# Altium schematic sheet [05] - MCU.SchDoc("[05] - MCU")
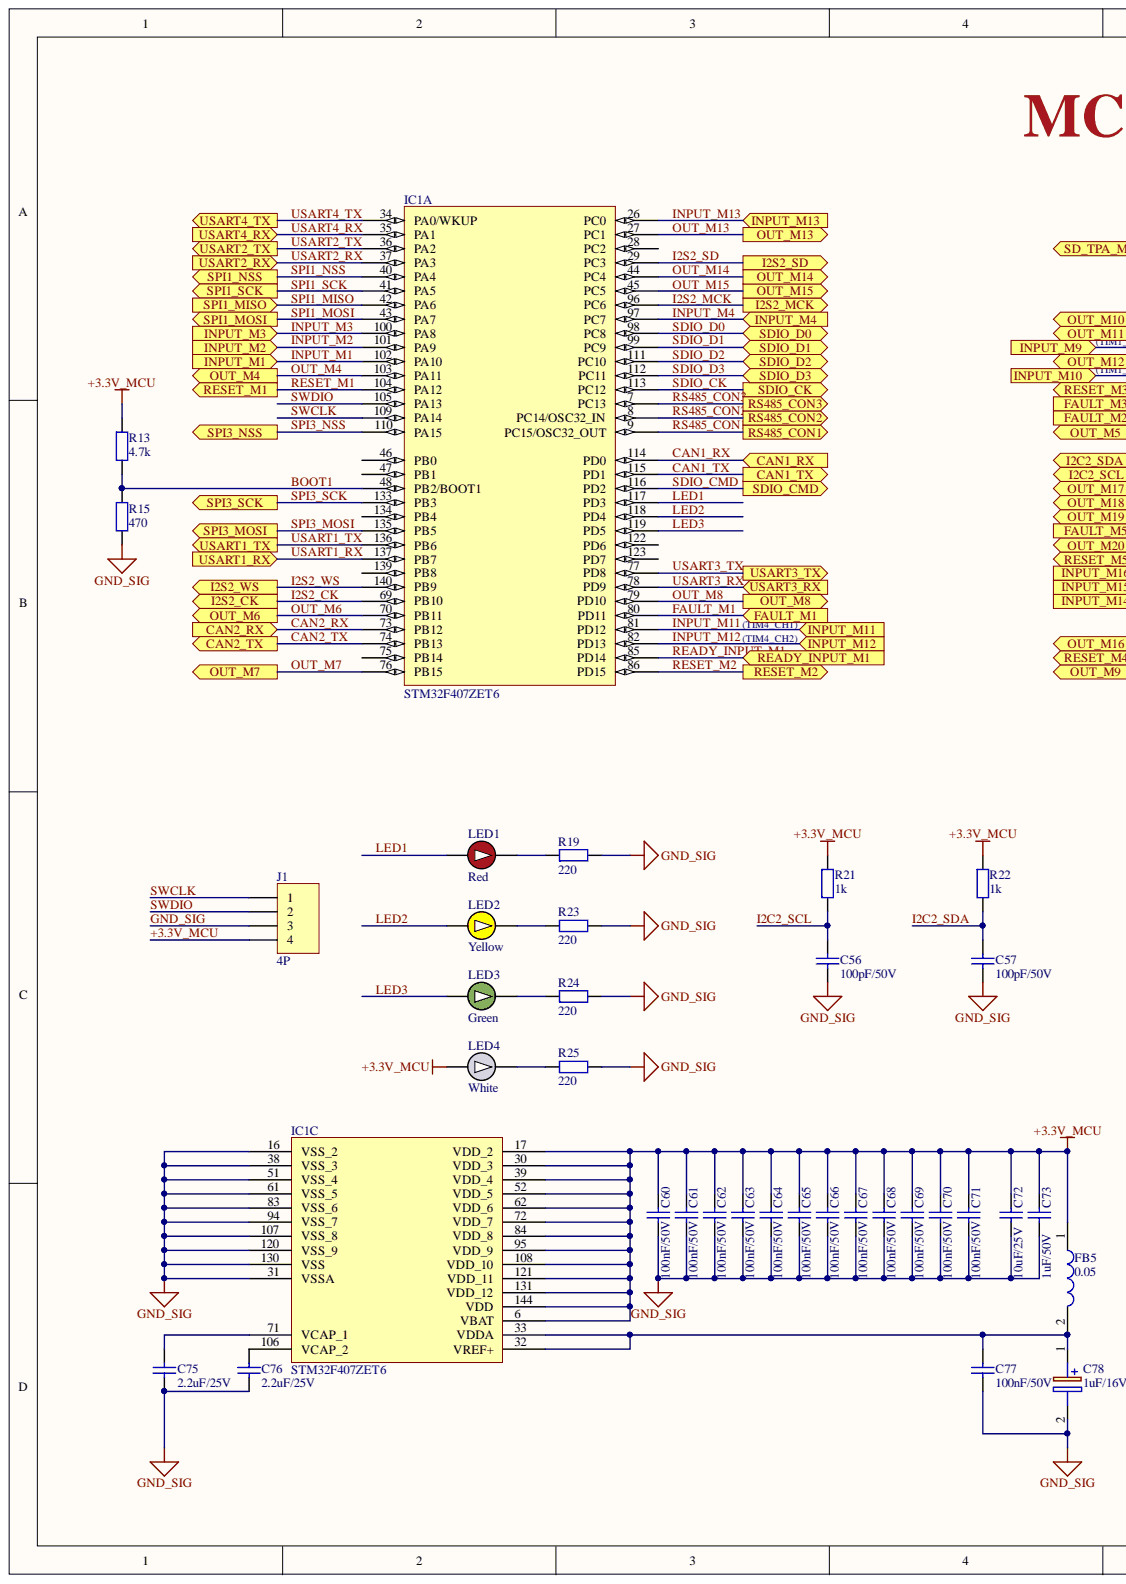
[diagram p0: [05] - MCU.SchDoc, left]
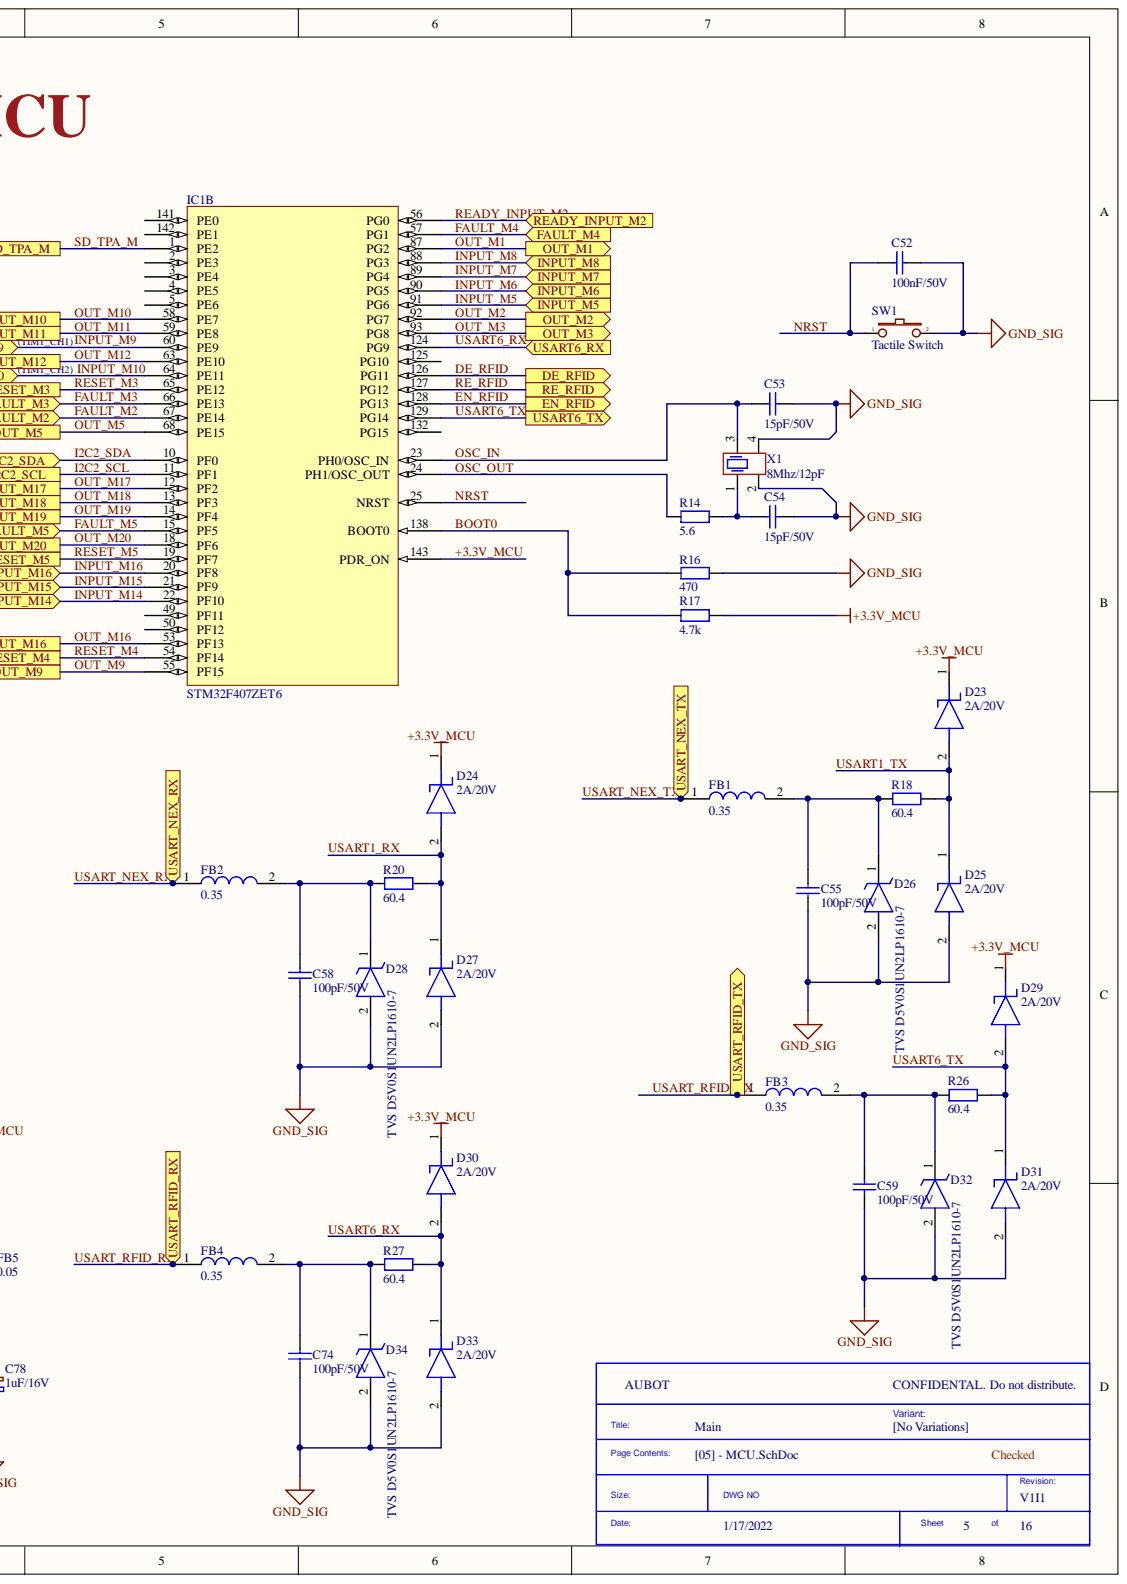
[diagram p1: [05] - MCU.SchDoc, right]

## Parts
C52  100nF/50V  [CAP 0805]
C53  15pF/50V  [CAP 0805]
C54  15pF/50V  [CAP 0805]
C55  100pF/50V  [CAP 0805]
C56  100pF/50V  [CAP 0805]
C57  100pF/50V  [CAP 0805]
C58  100pF/50V  [CAP 0805]
C59  100pF/50V  [CAP 0805]
C60  100nF/50V  [CAP 0805]
C61  100nF/50V  [CAP 0805]
C62  100nF/50V  [CAP 0805]
C63  100nF/50V  [CAP 0805]
C64  100nF/50V  [CAP 0805]
C65  100nF/50V  [CAP 0805]
C66  100nF/50V  [CAP 0805]
C67  100nF/50V  [CAP 0805]
C68  100nF/50V  [CAP 0805]
C69  100nF/50V  [CAP 0805]
C70  100nF/50V  [CAP 0805]
C71  100nF/50V  [CAP 0805]
C72  10uF/25V  [CAP 0805]
C73  1uF/50V  [CAP 0805]
C74  100pF/50V  [CAP 0805]
C75  2.2uF/25V  [CAP 0805]
C76  2.2uF/25V  [CAP 0805]
C77  100nF/50V  [CAP 0805]
C78  1uF/16V  [CAP TANT 1206]
D23  2A/20V  [DIODE SOD-323-2]
D24  2A/20V  [DIODE SOD-323-2]
D25  2A/20V  [DIODE SOD-323-2]
D26  TVS D5V0S1UN2LP1610-7  Manufacturer Part Number: D5V0S1UN2LP1610-7  [DFN110P158X55-2N]
D27  2A/20V  [DIODE SOD-323-2]
D28  TVS D5V0S1UN2LP1610-7  Manufacturer Part Number: D5V0S1UN2LP1610-7  [DFN110P158X55-2N]
D29  2A/20V  [DIODE SOD-323-2]
D30  2A/20V  [DIODE SOD-323-2]
D31  2A/20V  [DIODE SOD-323-2]
D32  TVS D5V0S1UN2LP1610-7  Manufacturer Part Number: D5V0S1UN2LP1610-7  [DFN110P158X55-2N]
D33  2A/20V  [DIODE SOD-323-2]
D34  TVS D5V0S1UN2LP1610-7  Manufacturer Part Number: D5V0S1UN2LP1610-7  [DFN110P158X55-2N]
FB1  0.35  [RES 0603]
FB2  0.35  [RES 0603]
FB3  0.35  [RES 0603]
FB4  0.35  [RES 0603]
FB5  0.05  [RES 0603]
IC1  STM32F407ZET6  [LQFP-144]  (parts A,B,C)
J1  4P  [HEADER 2.54 4P VERTICAL]
LED1  Red  [LED 0805]
LED2  Yellow  [LED 0805]
LED3  Green  [LED 0805]
LED4  White  [LED 0805]
R13  4.7k  [RES 0805]
R14  5.6  [RES 0805]
R15  470  [RES 0805]
R16  470  [RES 0805]
R17  4.7k  [RES 0805]
R18  60.4  [RES 0805]
R19  220  [RES 0805]
R20  60.4  [RES 0805]
R21  1k  [RES 0805]
R22  1k  [RES 0805]
R23  220  [RES 0805]
R24  220  [RES 0805]
R25  220  [RES 0805]
R26  60.4  [RES 0805]
R27  60.4  [RES 0805]
SW1  Tactile Switch  [Tactile Switch 6x3.5]
X1  8Mhz/12pF  [CRYSTAL 4P 3.2X2.5]

## Ports
CAN1_RX, CAN1_TX, CAN2_RX, CAN2_TX, DE_RFID, EN_RFID, FAULT_M1, FAULT_M2, FAULT_M3, FAULT_M4, FAULT_M5, I2C2_SCL, I2C2_SDA, I2S2_CK, I2S2_MCK, I2S2_SD, I2S2_WS, INPUT_M1, INPUT_M2, INPUT_M3, INPUT_M4, INPUT_M5, INPUT_M6, INPUT_M7, INPUT_M8, INPUT_M9, INPUT_M10, INPUT_M11, INPUT_M12, INPUT_M13, INPUT_M14, INPUT_M15, INPUT_M16, OUT_M1, OUT_M2, OUT_M3, OUT_M4, OUT_M5, OUT_M6, OUT_M7, OUT_M8, OUT_M9, OUT_M10, OUT_M11, OUT_M12, OUT_M13, OUT_M14, OUT_M15, OUT_M16, OUT_M17, OUT_M18, OUT_M19, OUT_M20, RE_RFID, READY_INPUT_M1, READY_INPUT_M2, RESET_M1, RESET_M2, RESET_M3, RESET_M4, RESET_M5, RS485_CON1, RS485_CON2, RS485_CON3, SD_TPA_M, SDIO_CK, SDIO_CMD, SDIO_D0, SDIO_D1, SDIO_D2, SDIO_D3, SPI1_MISO, SPI1_MOSI, SPI1_NSS, SPI1_SCK, SPI3_MOSI, SPI3_NSS, SPI3_SCK, USART1_RX, USART1_TX, USART2_RX, USART2_TX, USART3_RX, USART3_TX, USART4_RX, USART4_TX, USART6_RX, USART6_TX, USART_NEX_RX, USART_NEX_TX, USART_RFID_RX, USART_RFID_TX

## Nets
+3.3V_MCU: C60.2 C61.2 C62.2 C63.2 C64.2 C65.2 C66.2 C67.2 C68.2 C69.2 C70.2 C71.2 C72.2 C73.2 D23.1 D24.1 D29.1 D30.1 FB5.1 IC1.6(VBAT) IC1.17(VDD_2) IC1.30(VDD_3) IC1.39(VDD_4) IC1.52(VDD_5) IC1.62(VDD_6) IC1.72(VDD_7) IC1.84(VDD_8) IC1.95(VDD_9) IC1.108(VDD_10) IC1.121(VDD_11) IC1.131(VDD_12) IC1.143(PDR_ON) IC1.144(VDD) J1.4 LED4.1 R13.1 R17.2 R21.1 R22.1
BOOT0: IC1.138(BOOT0) R16.1 R17.1
BOOT1: IC1.48(PB2/BOOT1) R13.2 R15.1
CAN1_RX: IC1.114(PD0) ; port CAN1_RX
CAN1_TX: IC1.115(PD1) ; port CAN1_TX
CAN2_RX: IC1.73(PB12) ; port CAN2_RX
CAN2_TX: IC1.74(PB13) ; port CAN2_TX
DE_RFID: IC1.126(PG11) ; port DE_RFID
EN_RFID: IC1.128(PG13) ; port EN_RFID
FAULT_M1: IC1.80(PD11) ; port FAULT_M1
FAULT_M2: IC1.67(PE14) ; port FAULT_M2
FAULT_M3: IC1.66(PE13) ; port FAULT_M3
FAULT_M4: IC1.57(PG1) ; port FAULT_M4
FAULT_M5: IC1.15(PF5) ; port FAULT_M5
GND_SIG: C52.2 C53.1 C54.1 C55.2 C56.2 C57.2 C58.2 C59.2 C60.1 C61.1 C62.1 C63.1 C64.1 C65.1 C66.1 C67.1 C68.1 C69.1 C70.1 C71.1 C72.1 C73.1 C74.2 C75.1 C76.1 C77.1 C78.2 D25.2 D26.2 D27.2 D28.2 D31.2 D32.2 D33.2 D34.2 IC1.16(VSS_2) IC1.31(VSSA) IC1.38(VSS_3) IC1.51(VSS_4) IC1.61(VSS_5) IC1.83(VSS_6) IC1.94(VSS_7) IC1.107(VSS_8) IC1.120(VSS_9) IC1.130(VSS) J1.3 R15.2 R16.2 R19.2 R23.2 R24.2 R25.2 SW1.2 X1.2 X1.4
I2C2_SCL: C56.1 IC1.11(PF1) R21.2 ; port I2C2_SCL
I2C2_SDA: C57.1 IC1.10(PF0) R22.2 ; port I2C2_SDA
I2S2_CK: IC1.69(PB10) ; port I2S2_CK
I2S2_MCK: IC1.96(PC6) ; port I2S2_MCK
I2S2_SD: IC1.29(PC3) ; port I2S2_SD
I2S2_WS: IC1.140(PB9) ; port I2S2_WS
INPUT_M1: IC1.102(PA10) ; port INPUT_M1
INPUT_M2: IC1.101(PA9) ; port INPUT_M2
INPUT_M3: IC1.100(PA8) ; port INPUT_M3
INPUT_M4: IC1.97(PC7) ; port INPUT_M4
INPUT_M5: IC1.91(PG6) ; port INPUT_M5
INPUT_M6: IC1.90(PG5) ; port INPUT_M6
INPUT_M7: IC1.89(PG4) ; port INPUT_M7
INPUT_M8: IC1.88(PG3) ; port INPUT_M8
INPUT_M9: IC1.60(PE9) ; port INPUT_M9
INPUT_M10: IC1.64(PE11) ; port INPUT_M10
INPUT_M11: IC1.81(PD12) ; port INPUT_M11
INPUT_M12: IC1.82(PD13) ; port INPUT_M12
INPUT_M13: IC1.26(PC0) ; port INPUT_M13
INPUT_M14: IC1.22(PF10) ; port INPUT_M14
INPUT_M15: IC1.21(PF9) ; port INPUT_M15
INPUT_M16: IC1.20(PF8) ; port INPUT_M16
LED1: IC1.117(PD3) LED1.1
LED2: IC1.118(PD4) LED2.1
LED3: IC1.119(PD5) LED3.1
NRST: C52.1 IC1.25(NRST) SW1.1
OSC_IN: C53.2 IC1.23(PH0/OSC_IN) X1.3
OSC_OUT: IC1.24(PH1/OSC_OUT) R14.1
OUT_M1: IC1.87(PG2) ; port OUT_M1
OUT_M2: IC1.92(PG7) ; port OUT_M2
OUT_M3: IC1.93(PG8) ; port OUT_M3
OUT_M4: IC1.103(PA11) ; port OUT_M4
OUT_M5: IC1.68(PE15) ; port OUT_M5
OUT_M6: IC1.70(PB11) ; port OUT_M6
OUT_M7: IC1.76(PB15) ; port OUT_M7
OUT_M8: IC1.79(PD10) ; port OUT_M8
OUT_M9: IC1.55(PF15) ; port OUT_M9
OUT_M10: IC1.58(PE7) ; port OUT_M10
OUT_M11: IC1.59(PE8) ; port OUT_M11
OUT_M12: IC1.63(PE10) ; port OUT_M12
OUT_M13: IC1.27(PC1) ; port OUT_M13
OUT_M14: IC1.44(PC4) ; port OUT_M14
OUT_M15: IC1.45(PC5) ; port OUT_M15
OUT_M16: IC1.53(PF13) ; port OUT_M16
OUT_M17: IC1.12(PF2) ; port OUT_M17
OUT_M18: IC1.13(PF3) ; port OUT_M18
OUT_M19: IC1.14(PF4) ; port OUT_M19
OUT_M20: IC1.18(PF6) ; port OUT_M20
RE_RFID: IC1.127(PG12) ; port RE_RFID
READY_INPUT_M1: IC1.85(PD14) ; port READY_INPUT_M1
READY_INPUT_M2: IC1.56(PG0) ; port READY_INPUT_M2
RESET_M1: IC1.104(PA12) ; port RESET_M1
RESET_M2: IC1.86(PD15) ; port RESET_M2
RESET_M3: IC1.65(PE12) ; port RESET_M3
RESET_M4: IC1.54(PF14) ; port RESET_M4
RESET_M5: IC1.19(PF7) ; port RESET_M5
RS485_CON1: IC1.9(PC15/OSC32_OUT) ; port RS485_CON1
RS485_CON2: IC1.8(PC14/OSC32_IN) ; port RS485_CON2
RS485_CON3: IC1.7(PC13) ; port RS485_CON3
SD_TPA_M: IC1.1(PE2) ; port SD_TPA_M
SDIO_CK: IC1.113(PC12) ; port SDIO_CK
SDIO_CMD: IC1.116(PD2) ; port SDIO_CMD
SDIO_D0: IC1.98(PC8) ; port SDIO_D0
SDIO_D1: IC1.99(PC9) ; port SDIO_D1
SDIO_D2: IC1.111(PC10) ; port SDIO_D2
SDIO_D3: IC1.112(PC11) ; port SDIO_D3
SPI1_MISO: IC1.42(PA6) ; port SPI1_MISO
SPI1_MOSI: IC1.43(PA7) ; port SPI1_MOSI
SPI1_NSS: IC1.40(PA4) ; port SPI1_NSS
SPI1_SCK: IC1.41(PA5) ; port SPI1_SCK
SPI3_MOSI: IC1.135(PB5) ; port SPI3_MOSI
SPI3_NSS: IC1.110(PA15) ; port SPI3_NSS
SPI3_SCK: IC1.133(PB3) ; port SPI3_SCK
SWCLK: IC1.109(PA14) J1.1
SWDIO: IC1.105(PA13) J1.2
USART1_RX: D24.2 D27.1 IC1.137(PB7) R20.2 ; port USART1_RX
USART1_TX: D23.2 D25.1 IC1.136(PB6) R18.2 ; port USART1_TX
USART2_RX: IC1.37(PA3) ; port USART2_RX
USART2_TX: IC1.36(PA2) ; port USART2_TX
USART3_RX: IC1.78(PD9) ; port USART3_RX
USART3_TX: IC1.77(PD8) ; port USART3_TX
USART4_RX: IC1.35(PA1) ; port USART4_RX
USART4_TX: IC1.34(PA0/WKUP) ; port USART4_TX
USART6_RX: D30.2 D33.1 IC1.124(PG9) R27.2 ; port USART6_RX
USART6_TX: D29.2 D31.1 IC1.129(PG14) R26.2 ; port USART6_TX
USART_NEX_RX: FB2.1 ; port USART_NEX_RX
USART_NEX_TX: FB1.1 ; port USART_NEX_TX
USART_RFID_RX: FB4.1 ; port USART_RFID_RX
USART_RFID_TX: FB3.1 ; port USART_RFID_TX
NetC54_2: C54.2 R14.2 X1.1
NetC55_1: C55.1 D26.1 FB1.2 R18.1
NetC58_1: C58.1 D28.1 FB2.2 R20.1
NetC59_1: C59.1 D32.1 FB3.2 R26.1
NetC74_1: C74.1 D34.1 FB4.2 R27.1
NetC75_2: C75.2 IC1.71(VCAP_1)
NetC76_2: C76.2 IC1.106(VCAP_2)
NetC77_2: C77.2 C78.1 FB5.2 IC1.32(VREF+) IC1.33(VDDA)
NetLED1_2: LED1.2 R19.1
NetLED2_2: LED2.2 R23.1
NetLED3_2: LED3.2 R24.1
NetLED4_2: LED4.2 R25.1

Not connected: IC1 2(PE3) 3(PE4) 4(PE5) 5(PE6) 28(PC2) 46(PB0) 47(PB1) 49(PF11) 50(PF12) 75(PB14) 122(PD6) 123(PD7) 125(PG10) 132(PG15) 134(PB4) 139(PB8) 141(PE0) 142(PE1)

## Library data of the parts
C52 C60-C71 C77 = Cap 0805 100nF/50V: Ceramic capacitor SMD-0805 100nF /50V X7R# K +-10%, Samsung, RoHS; Manufacturer Part Number: CL21B104KBCNNNC; Voltage Rating (DC): 50V; Tolerance: 10%; Dielectric: X7R; Width: 0.049inch; Voltage Rating: 50V; Voltage: 50V; Thickness: 0.037inch; Supplier Part Number 1: C1711; Supplier 1: LCSC; Stock 1: 447650; Series: CL; RoHS Compliant: Yes; RoHS: Yes; Radiation Hardening: No; Pricing 1: 50 = 0.04090, 500 = 0.03130, 1500 = 0.02890, 5000 = 0.02580, 25000 = 0.02430, 50000 = 0.02410, 50 = 0.03950, 500 = 0.03020, 1500 = 0.02790, 5000 = 0.02490, 25000 = 0.02350, 50000 = 0.02330, 50 = 0.20680, 500 = 0.15820, 1500 = 0.14600, 5000 = 0.13020, 25000 = 0.12290, 50000 = 0.12170, 50 = 0.19640, 500 = 0.15020, 1500 = 0.13860, 5000 = 0.12360, 25000 = 0.11670, 50000 = 0.11550, 50 = 0.02640, 500 = 0.02020, 1500 = 0.01860, 5000 = 0.01660, 25000 = 0.01570, 50000 = 0.01550, 50 = 0.02300, 500 = 0.01760, 1500 = 0.01620, 5000 = 0.01440, 25000 = 0.01360, 50000 = 0.01350, 50 = 0.24740, 500 = 0.18920, 1500 = 0.17470, 5000 = 0.15570, 25000 = 0.14700, 50000 = 0.14560, 50 = 3.45220, 500 = 2.64000, 1500 = 2.43700, 5000 = 2.17300, 25000 = 2.05110, 50000 = 2.03080, 50 = 0.13070, 500 = 0.09990, 1500 = 0.09220, 5000 = 0.08230, 25000 = 0.07760, 50000 = 0.07690, 50 = 2.39050, 500 = 1.82810, 1500 = 1.68750, 5000 = 1.50470, 25000 = 1.42030, 50000 = 1.40620, 50 = 0.26740, 500 = 0.20450, 1500 = 0.18870, 5000 = 0.16830, 25000 = 0.15890, 50000 = 0.15730, 50 = 0.04230, 500 = 0.03230, 1500 = 0.02990, 5000 = 0.02660, 25000 = 0.02510, 50000 = 0.02490, 50 = 0.03188, 500 = 0.02438, 1500 = 0.02251, 5000 = 0.02007, 25000 = 0.01894, 50000 = 0.01875 (AUD); Packaging: Tape and Reel; Mount: Surface Mount; Min Operating Temperature: -55°C; Max Operating Temperature: 125°C; Material: Ceramic; Manufacturer: Samsung; Length: 2mm; Lead Free: Lead Free; Height: 0.85mm; Depth: 1.25mm; Contact Plating: Tin; Construction: Flat; ComponentLink2URL: https://datasheet.ciiva.com/29199/454152-da-01-en-vs-kondensator-0805-29199814.pdf?src-supplier=Conrad; ComponentLink2Description: https://datasheet.ciiva.com/29199/454152-da-01-en-vs-kondensator-0805-29199814.pdf?src-supplier=Conrad; ComponentLink1URL: https://datasheet.ciiva.com/25710/cl21b104kbcnnnc-25710182.pdf?src-supplier=Digi-Key; ComponentLink1Description: https://datasheet.ciiva.com/25710/cl21b104kbcnnnc-25710182.pdf?src-supplier=Digi-Key; Component Kind: Standard; Case Code (Metric): 2012; Case Code (Imperial): 0805; Case/Package: 0805; Capacitance: 100nF; Library Name: Hieu_Lib.IntLib
C53 C54 = Cap 0805 15pF/50V: Cap, AEC-Q200, 15PF, 50V, Mlcc, 0805;; Manufacturer Part Number: C0805C150K5RACAUTO; Manufacturer: KEMET; Supplier Part Number 1: 80-C0805C150K5RAUTO; Supplier 1: Mouser; Stock 1: 14030; RoHS Compliant: Yes; RoHS: Yes; Pricing 1: 1 = 0.31000, 10 = 0.20400, 50 = 0.20400, 100 = 0.10400, 1000 = 0.07000, 10000 = 0.06000 (USD); Component Kind: Standard; Library Name: Hieu_Lib.IntLib
C55-C59 C74 = Cap 0805 100pF/50V: 0805 100 pF 50 V ±10 % Tolerance X7R SMT Multilayer Ceramic Capacitor; Manufacturer Part Number: CC0805KRX7R9BB101; Manufacturer: Yageo; Voltage Rating (DC): 50V; Tolerance: 10%; Capacitance: 100pF; Width: 0.049inch; Weight: 0.000194oz; Voltage Rating: 50V; Thickness: 0.028inch; Termination: SMD/SMT; Supplier Part Number 1: 311-3603-1-ND; Supplier 1: Digi-Key; Stock 1: 33076; Series: CC; RoHS Compliant: Yes; RoHS: Yes; Resistor Type: Ceramic; Radiation Hardening: No; Pricing 1: 1 = 0.12000, 10 = 0.08500, 50 = 0.04840, 100 = 0.04010, 500 = 0.02810, 1000 = 0.02326 (USD); Packaging: Tape and Reel; Mount: Surface Mount; Min Operating Temperature: -55°C; Max Operating Temperature: 125°C; Length: 2mm; Lead Free: Lead Free; Halogen Free: Halogen Free; Dielectric: X7R; Depth: 1.25mm; Construction: Flat; ComponentLink2URL: https://datasheet.ciiva.com/17436/upy-gphc-x7r-6-3v-to-50v-8-17436651.pdf?src-supplier=Avnet+Europe; ComponentLink2Description: https://datasheet.ciiva.com/17436/upy-gphc-x7r-6-3v-to-50v-8-17436651.pdf?src-supplier=Avnet+Europe; ComponentLink1URL: https://datasheet.ciiva.com/11465/upy-gphc-x7r-6-3v-to-50v-8-11465306.pdf?src-supplier=Avnet; ComponentLink1Description: https://datasheet.ciiva.com/11465/upy-gphc-x7r-6-3v-to-50v-8-11465306.pdf?src-supplier=Avnet; Component Kind: Standard; Case Code (Metric): 2012; Case Code (Imperial): 0805; Case/Package: 0805; Library Name: Hieu_Lib.IntLib
C72 = Cap 0805 10uF/25V: 0805 10 uF 25 V ±10 % Tolerance X5R SMT Multilayer Ceramic Capacitor; Manufacturer Part Number: CC0805KKX5R8BB106; Manufacturer: Yageo; Voltage Rating (DC): 25V; Tolerance: 10%; Capacitance: 10uF; Width: 0.049inch; Weight: 0.000194oz; Voltage Rating: 25V; Thickness: 0.057inch; Termination: SMD/SMT; Supplier Part Number 1: 311-1869-1-ND; Supplier 1: Digi-Key; Stock 1: 149609; Series: CC; RoHS Compliant: Yes; RoHS: Yes; Resistor Type: Ceramic; Pricing 1: 1 = 0.55000, 10 = 0.38500, 50 = 0.26960, 100 = 0.23120, 500 = 0.17336, 1000 = 0.14640 (USD); Packaging: Tape and Reel; Mount: Surface Mount; Min Operating Temperature: -55°C; Max Operating Temperature: 85°C; Length: 0.079inch; Lead Free: Lead Free; Halogen Free: Halogen Free; Dielectric: X5R; Depth: 1.25mm; ComponentLink3URL: http://www.yageo.com/documents/recent/UPY-GPHC_X5R_4V-to-50V_25.pdf?src-supplier=Digi-Key; ComponentLink3Description: http://www.yageo.com/documents/recent/UPY-GPHC_X5R_4V-to-50V_25.pdf?src-supplier=Digi-Key; ComponentLink2URL: http://www.mouser.com/ds/2/447/UPY-GPHC_X5R_4V-to-50V_25-1131599.pdf?src-supplier=Mouser; ComponentLink2Description: http://www.mouser.com/ds/2/447/UPY-GPHC_X5R_4V-to-50V_25-1131599.pdf?src-supplier=Mouser; ComponentLink1URL: http://components.arrow.com/part/dataview/0323_04166572?src-supplier=Arrow+Europe; ComponentLink1Description: http://components.arrow.com/part/dataview/0323_04166572?src-supplier=Arrow+Europe; Component Kind: Standard; Case Code (Metric): 2012; Case Code (Imperial): 0805; Case/Package: 0805; Library Name: Hieu_Lib.SchLib
C73 = Cap 0805 1uF/50V: 0805 1 uF 50 V ±10 % Tolerance X7R SMT Multilayer Ceramic Capacitor; Manufacturer Part Number: CC0805KKX7R9BB105; Manufacturer: Yageo; Voltage Rating (DC): 50V; Tolerance: 10%; Capacitance: 1uF; Width: 0.049inch; Weight: 0.000194oz; Voltage Rating: 50V; Thickness: 0.057inch; Termination: SMD/SMT; Supplier Part Number 1: 311-1886-1-ND; Supplier 1: Digi-Key; Stock 1: 174238; Series: CC; RoHS Compliant: Yes; RoHS: Yes; Resistor Type: Ceramic; Radiation Hardening: No; Pricing 1: 1 = 0.26000, 10 = 0.17900, 50 = 0.11920, 100 = 0.10130, 500 = 0.07152, 1000 = 0.06257 (USD); Packaging: Tape and Reel; Mount: Surface Mount; Min Operating Temperature: -55°C; Max Operating Temperature: 125°C; Length: 2mm; Lead Free: Lead Free; Height: 1.25mm; Halogen Free: Halogen Free; Dielectric: X7R; Depth: 1.25mm; Construction: Flat; ComponentLink1URL: https://datasheet.ciiva.com/13209/upy-gphc-x7r-6-3v-to-50v-8-12304-13209375.pdf?src-supplier=Mouser; ComponentLink1Description: https://datasheet.ciiva.com/13209/upy-gphc-x7r-6-3v-to-50v-8-12304-13209375.pdf?src-supplier=Mouser; Component Kind: Standard; Case Code (Metric): 2012; Case Code (Imperial): 0805; Case/Package: 0805; Library Name: Hieu_Lib.IntLib
C75 C76 = Cap 0805 2.2uF/25V: YAGEO - CC0805KKX7R8BB225 - SMD Multilayer Ceramic Capacitor, 2.2 µF, 25 V, 0805 [2012 Metric], ± 10%, X7R, CC Series; Voltage Rating (DC): 25V; Capacitance: 2.2uF; Width: 1.25mm; Voltage Rating: 25V; Tolerance: 10%; Thickness: 0.057inch; Termination: SMD/SMT; Supplier Part Number 1: 603-CC805KKX7R8BB225; Supplier 1: Mouser; Stock 1: 57440; Series: CC; RoHS Compliant: Yes; RoHS: Yes; Resistor Type: Ceramic; REACH SVHC: Unknown; Radiation Hardening: No; Pricing 1: 1 = 0.38000, 10 = 0.20600, 50 = 0.20600, 100 = 0.15000, 1000 = 0.09500, 10000 = 0.08900 (USD); Packaging: Tape and Reel; Number of Pins: 2; Mount: Surface Mount; Min Operating Temperature: -55°C; Max Operating Temperature: 125°C; Manufacturer Part Number: CC0805KKX7R8BB225; Manufacturer: Yageo; Length: 2mm; Lead Free: Lead Free; Height: 0.3mm; Halogen Free: Halogen Free; Dielectric: X7R; Depth: 1.25mm; ComponentLink1URL: https://datasheet.ciiva.com/7009/775840-7009706.pdf?src-supplier=Newark; ComponentLink1Description: https://datasheet.ciiva.com/7009/775840-7009706.pdf?src-supplier=Newark; Component Kind: Standard; Case Code (Metric): 2012; Case Code (Imperial): 0805; Case/Package: 0805; Library Name: Hieu_Lib.SchLib
C78 = Cap Tant 1206 1uF/16V: Cap Tant Solid 1uF 16V A CASE 10%( 3.2 X 1.6 X 1.6mm) Inward L SMD 3216-18 11 Ohm 125C T/R; Manufacturer Part Number: TAJA105K016RNJ; Manufacturer: Kyocera AVX; Tolerance: 20%; Polarity: Polar; Leakage Current: 500nA; ESR (Equivalent Series Resistance): 11R; Dissipation Factor: 4%; Dielectric Material: Tantalum; Width: 1.6mm; Weight: 0.000571oz; Voltage Rating (DC): 16V; Voltage Rating: 16V; Voltage: 16V; Termination: SMD/SMT; Supplier Part Number 1: 478-1649-1-ND; Supplier 1: Digi-Key; Stock 1: 10000; Series: TAJ; RoHS Compliant: Yes; RoHS: Yes; REACH SVHC: No SVHC; Radiation Hardening: No; Pricing 1: 1 = 0.37000, 10 = 0.25500, 50 = 0.17880, 100 = 0.15320, 500 = 0.11488, 1000 = 0.09701 (USD); Packaging: Tape and Reel; Package Quantity: 1; Number of Pins: 2; Mount: Surface Mount,PCB; Min Operating Temperature: -55°C; Max Operating Temperature: 125°C; Length: 3.2mm; Lead Pitch: 1.1mm; Lead Free: Lead Free; Height - Seated (Max): 0.071inch; Height: 1.6mm; Features: General Purpose; Depth: 1.6mm; ComponentLink9URL: https://datasheet.ciiva.com/7832/1680476-7832431.pdf?src-supplier=Element14; ComponentLink9Description: https://datasheet.ciiva.com/7832/1680476-7832431.pdf?src-supplier=Element14; ComponentLink8URL: https://datasheet.ciiva.com/7832/1703059-7832429.pdf?src-supplier=Element14; ComponentLink8Description: https://datasheet.ciiva.com/7832/1703059-7832429.pdf?src-supplier=Element14; ComponentLink7URL: https://datasheet.ciiva.com/7832/taj-7832811.pdf?src-supplier=Element14; ComponentLink7Description: https://datasheet.ciiva.com/7832/taj-7832811.pdf?src-supplier=Element14; ComponentLink6URL: https://datasheet.ciiva.com/18710/taj-eng-18710647.pdf?src-supplier=Distrelec; ComponentLink6Description: https://datasheet.ciiva.com/18710/taj-eng-18710647.pdf?src-supplier=Distrelec; ComponentLink5URL: https://datasheet.ciiva.com/263/taj-263409.pdf?src-supplier=Digi-Key; ComponentLink5Description: https://datasheet.ciiva.com/263/taj-263409.pdf?src-supplier=Digi-Key; ComponentLink4URL: https://datasheet.ciiva.com/7832/1703059-7832430.pdf?src-supplier=Element14; ComponentLink4Description: https://datasheet.ciiva.com/7832/1703059-7832430.pdf?src-supplier=Element14; ComponentLink3URL: https://datasheet.ciiva.com/7832/taj-7832810.pdf?src-supplier=Element14; ComponentLink3Description: https://datasheet.ciiva.com/7832/taj-7832810.pdf?src-supplier=Element14; ComponentLink2URL: https://datasheet.ciiva.com/263/taj-263411.pdf?src-supplier=Digi-Key; ComponentLink2Description: https://datasheet.ciiva.com/263/taj-263411.pdf?src-supplier=Digi-Key; ComponentLink1URL: https://datasheet.ciiva.com/263/taj-263410.pdf?src-supplier=Digi-Key; ComponentLink1Description: https://datasheet.ciiva.com/263/taj-263410.pdf?src-supplier=Digi-Key; Component Kind: Standard; Case Code (Metric): 3216; Case Code (Imperial): 1206; Case/Package: 1206; Capacitance: 1uF; Library Name: Hieu_Lib.IntLib
D23-D25 D27 D29-D31 D33 = Diode Schottky 2A: SDM2U20SD3 Series 20 V 2 A Surface Mount Schottky Barrier Rectifier - SOD-323; Manufacturer Part Number: SDM2U20SD3-7; Manufacturer: Diodes; Max Reverse Voltage (DC): 20V; Average Rectified Current: 2A; Supplier Part Number 1: 621-SDM2U20SD3-7; Supplier 1: Mouser; Stock 1: 485; RoHS Compliant: Yes; RoHS: Yes; Pricing 1: 1 = 0.46000, 10 = 0.35200, 50 = 0.35200, 100 = 0.20000, 1000 = 0.10200, 10000 = 0.07000 (USD); Packaging: Cut Tape; Mount: Surface Mount; ComponentLink3URL: http://diodes.com/datasheets/SDM2U20SD3.pdf?src-supplier=Avnet; ComponentLink3Description: http://diodes.com/datasheets/SDM2U20SD3.pdf?src-supplier=Avnet; ComponentLink2URL: https://www.diodes.com/assets/Datasheets/SDM2U20SD3.pdf?src-supplier=Digi-Key; ComponentLink2Description: https://www.diodes.com/assets/Datasheets/SDM2U20SD3.pdf?src-supplier=Digi-Key; ComponentLink1URL: http://www.mouser.com/ds/2/115/SDM2U20SD3-537776.pdf?src-supplier=Mouser; ComponentLink1Description: http://www.mouser.com/ds/2/115/SDM2U20SD3-537776.pdf?src-supplier=Mouser; Component Kind: Standard; Library Name: Hieu_Lib.IntLib
D26 D28 D32 D34 = TVS D5V0S1UN2LP1610-7: ESD Suppressors / TVS Diodes Surge Protection PP U-DFN1610-2 T&R 10K; Supplier Part Number 1: 621-D5V0S1UN2LP16107; Supplier 1: Mouser; Stock 1: 48367; RoHS: No; Pricing 1: 1 = 0.42000, 10 = 0.32000, 50 = 0.32000, 100 = 0.19900, 1000 = 0.10500, 10000 = 0.08100 (USD); Manufacturer: Diodes; Component Kind: Standard; Library Name: Hieu_Lib.IntLib
FB1-FB4 = Ferrite Beads 0603 470 Ohm 100Mhz: Ferrite Beads Multi-Layer 470Ohm 25% 100MHz 500mA 350mOhm DCR 0603 Paper T/R; Manufacturer Part Number: BLM18AG471SN1D; Manufacturer: Murata; Shielding: Unshielded; Number of Lines: 1; Material: Ferrite,Steel; Impedance: 470R; DC Resistance-Max: 350mR; Width: 0.8mm; Tolerance: 25%; Test Frequency: 100MHz; Termination: SMD/SMT; Supplier Part Number 1: 490-1013-1-ND; Supplier 1: Digi-Key; Stock 1: 83235; Series: BLM18A; RoHS Compliant: Yes; RoHS: Yes; REACH SVHC: No SVHC; Radiation Hardening: No; Pricing 1: 1 = 0.10000, 10 = 0.07900, 25 = 0.07200, 50 = 0.06480, 100 = 0.04760, 250 = 0.03460, 500 = 0.03170, 1000 = 0.02738 (USD); Packaging: Tape and Reel; Package Quantity: 1; Number of Pins: 2; Number of Circuits: 1; Min Operating Temperature: -55°C; Military Standard: Not; Max Operating Temperature: 125°C; Max DC Current: 500mA; Max Current Rating: 500mA; Length: 1.6mm; Lead Free: Lead Free; Height: 0.8mm; Frequency: 100MHz; Depth: 0.8mm; DC Resistance (DCR): 350mR; DC Current: 500mA; Current Rating: 500mA; Core Material: Ferrite; ComponentLink10URL: https://datasheet.ciiva.com/14122/blm-series-eng-tds-14122873.pdf?src-supplier=Distrelec; ComponentLink10Description: https://datasheet.ciiva.com/14122/blm-series-eng-tds-14122873.pdf?src-supplier=Distrelec; ComponentLink9URL: https://datasheet.ciiva.com/8510/l0110s0100blm18a-8510056.pdf?src-supplier=Avnet; ComponentLink9Description: https://datasheet.ciiva.com/8510/l0110s0100blm18a-8510056.pdf?src-supplier=Avnet; ComponentLink8URL: https://datasheet.ciiva.com/1012/l0110s0100blm18a-1012037.pdf?src-supplier=Digi-Key; ComponentLink8Description: https://datasheet.ciiva.com/1012/l0110s0100blm18a-1012037.pdf?src-supplier=Digi-Key; ComponentLink7URL: https://datasheet.ciiva.com/1466/356366-1466932.pdf?src-supplier=Element14; ComponentLink7Description: https://datasheet.ciiva.com/1466/356366-1466932.pdf?src-supplier=Element14; ComponentLink6URL: https://datasheet.ciiva.com/1012/l0110s0100blm18a-1012036.pdf?src-supplier=Digi-Key; ComponentLink6Description: https://datasheet.ciiva.com/1012/l0110s0100blm18a-1012036.pdf?src-supplier=Digi-Key; ComponentLink5URL: https://datasheet.ciiva.com/1466/356366-1466931.pdf?src-supplier=Element14; ComponentLink5Description: https://datasheet.ciiva.com/1466/356366-1466931.pdf?src-supplier=Element14; ComponentLink4URL: https://datasheet.ciiva.com/1012/l0110s0100blm18a-1012035.pdf?src-supplier=Digi-Key; ComponentLink4Description: https://datasheet.ciiva.com/1012/l0110s0100blm18a-1012035.pdf?src-supplier=Digi-Key; ComponentLink3URL: https://datasheet.ciiva.com/41449/001172226-da-01-en-drossel-0603-470r-1-6x0-8mm-4000st-41449516.pdf?src-supplier=Conrad; ComponentLink3Description: https://datasheet.ciiva.com/41449/001172226-da-01-en-drossel-0603-470r-1-6x0-8mm-4000st-41449516.pdf?src-supplier=Conrad; ComponentLink2URL: https://datasheet.ciiva.com/29347/442296-da-01-en-chip-drossel-0603-470r-500ma-1-6x0-8mm-29347895.pdf?src-supplier=Conrad; ComponentLink2Description: https://datasheet.ciiva.com/29347/442296-da-01-en-chip-drossel-0603-470r-500ma-1-6x0-8mm-29347895.pdf?src-supplier=Conrad; ComponentLink1URL: https://datasheet.ciiva.com/11800/0900766b811b5866-11800883.pdf?src-supplier=RSComponents; ComponentLink1Description: https://datasheet.ciiva.com/11800/0900766b811b5866-11800883.pdf?src-supplier=RSComponents; Component Kind: Standard; Case Code (Metric): 1608; Case Code (Imperial): 0603; Case/Package: 0603; Library Name: Hieu_Lib.IntLib
FB5 = Ferrite Bead 0603 30Ohm 100Mhz: FERRITE BEAD 30 OHM 0603 1LN / Ferrite Beads Multi-Layer 30Ohm 100MHz 1A 0.05Ohm DCR 0603 T/R; Manufacturer Part Number: BLM18PG300SN1D; Manufacturer: Murata; Tolerance: 25%; Shielding: Unshielded; Number of Lines: 1; Material: Steel,Ferrite; Impedance: 30R; DC Resistance-Max: 50mR; Width: 0.8mm; Test Frequency: 100MHz; Termination: SMD/SMT; Supplier Part Number 1: 490-1035-1-ND; Supplier 1: Digi-Key; Stock 1: 1731; Series: BLM18P; RoHS Compliant: Yes; RoHS: Yes; REACH SVHC: No SVHC; Radiation Hardening: No; Pricing 1: 1 = 0.10000, 10 = 0.08300, 25 = 0.07600, 50 = 0.06840, 100 = 0.05010, 250 = 0.03644, 500 = 0.03340, 1000 = 0.02884 (USD); Packaging: Tape and Reel; Package Quantity: 4000; Number of Pins: 2; Number of Circuits: 1; Min Operating Temperature: -55°C; Max Operating Temperature: 125°C; Max DC Current: 1A; Max Current Rating: 1A; Length: 1.6mm; Lead Free: Lead Free; Height: 0.8mm; Frequency: 100MHz; Depth: 0.8mm; DC Resistance (DCR): 50mR; DC Current: 1A; Current Rating: 1A; Core Material: Ferrite; ComponentLink11URL: https://datasheet.ciiva.com/8021/5148-8021486.pdf?src-supplier=Element14; ComponentLink11Description: https://datasheet.ciiva.com/8021/5148-8021486.pdf?src-supplier=Element14; ComponentLink10URL: https://datasheet.ciiva.com/17246/l0110s0100blm18p-17246940.pdf?src-supplier=Avnet+Europe; ComponentLink10Description: https://datasheet.ciiva.com/17246/l0110s0100blm18p-17246940.pdf?src-supplier=Avnet+Europe; ComponentLink9URL: https://datasheet.ciiva.com/8021/5148-8021484.pdf?src-supplier=Element14; ComponentLink9Description: https://datasheet.ciiva.com/8021/5148-8021484.pdf?src-supplier=Element14; ComponentLink8URL: https://datasheet.ciiva.com/1012/l0110s0100blm18p-1012121.pdf?src-supplier=Digi-Key; ComponentLink8Description: https://datasheet.ciiva.com/1012/l0110s0100blm18p-1012121.pdf?src-supplier=Digi-Key; ComponentLink7URL: https://datasheet.ciiva.com/1012/l0110s0100blm18p-1012120.pdf?src-supplier=Digi-Key; ComponentLink7Description: https://datasheet.ciiva.com/1012/l0110s0100blm18p-1012120.pdf?src-supplier=Digi-Key; ComponentLink6URL: https://datasheet.ciiva.com/16420/l0110s0100blm18p-16420743.pdf?src-supplier=Future+Electronics; ComponentLink6Description: https://datasheet.ciiva.com/16420/l0110s0100blm18p-16420743.pdf?src-supplier=Future+Electronics; ComponentLink5URL: https://datasheet.ciiva.com/1012/l0110s0100blm18p-1012119.pdf?src-supplier=Digi-Key; ComponentLink5Description: https://datasheet.ciiva.com/1012/l0110s0100blm18p-1012119.pdf?src-supplier=Digi-Key; ComponentLink4URL: https://datasheet.ciiva.com/3081/l0110s0100blm18p-3081636.pdf?src-supplier=Avnet; ComponentLink4Description: https://datasheet.ciiva.com/3081/l0110s0100blm18p-3081636.pdf?src-supplier=Avnet; ComponentLink3URL: https://datasheet.ciiva.com/3081/l0110s0100blm18p-3081635.pdf?src-supplier=Avnet; ComponentLink3Description: https://datasheet.ciiva.com/3081/l0110s0100blm18p-3081635.pdf?src-supplier=Avnet; ComponentLink2URL: https://datasheet.ciiva.com/19460/1845048-19460200.pdf?src-supplier=Jameco; ComponentLink2Description: https://datasheet.ciiva.com/19460/1845048-19460200.pdf?src-supplier=Jameco; ComponentLink1URL: https://datasheet.ciiva.com/11800/0900766b81275ca5-11800868.pdf?src-supplier=RSComponents; ComponentLink1Description: https://datasheet.ciiva.com/11800/0900766b81275ca5-11800868.pdf?src-supplier=RSComponents; Component Kind: Standard; Case Code (Metric): 1608; Case Code (Imperial): 0603; Case/Package: 0603; Library Name: Hieu_Lib.IntLib
IC1 = STM32F407ZET6: MCU 32-bit STM32F ARM Cortex M4F RISC 512KB Flash 2.5V/3.3V 144-Pin LQFP Tray; Manufacturer: STMicroelectronics; Watchdog Timer: Yes; RAM Size: 192KB; Peripherals: Brown-out Detect/Reset,POR,PWM,WDT,DMA,LCD,I2C; Oscillator Type: Internal; Number of USB Channels: 2; Number of Timers/Counters: 14; Number of SPI Channels: 3; Number of Programmable I/O: 114; Number of Ethernet Channels: 1; Number of ADC Channels: 24; Min Supply Voltage: 1.8V; Max Supply Voltage: 3.6V; Max Frequency: 168MHz; Interface: I2C,SPI,CAN,I2S,UART,USB,Ethernet,IrDA,USART,LIN,EBI/EMI; Data Bus Width: 32b; Width: 20.2mm; Supplier Part Number 1: 511-STM32F407ZET6; Supplier 1: Mouser; Stock 1: 0; RoHS: Yes; REACH SVHC: No SVHC; Radiation Hardening: No; Pricing 1: 1 = 13.53000, 10 = 12.45000, 25 = 11.93000, 50 = 11.93000, 100 = 10.51000, 250 = 9.99000, 360 = 9.34000, 1000 = 9.34000, 1080 = 8.59000, 10000 = 9.34000 (USD); Packaging: Tray; Package Quantity: 60; Number of UART Channels: 2; Number of Pins: 144; Number of I/Os: 114; Number of I2C Channels: 3; Min Operating Temperature: -40°C; Memory Type: FLASH; Memory Size: 512KB; Max Operating Temperature: 85°C; Manufacturer Part Number: STM32F407ZET6; Length: 20.2mm; Lead Free: Lead Free; Height: 1.45mm; Frequency: 168MHz; Core Architecture: ARM; ComponentLink2URL: https://datasheet.ciiva.com/6640/dm00037051-6640863.pdf?src-supplier=Digi-Key; ComponentLink2Description: https://datasheet.ciiva.com/6640/dm00037051-6640863.pdf?src-supplier=Digi-Key; ComponentLink1URL: https://datasheet.ciiva.com/16378/dm00037051-16378957.pdf?src-supplier=Future+Electronics; ComponentLink1Description: https://datasheet.ciiva.com/16378/dm00037051-16378957.pdf?src-supplier=Future+Electronics; Component Kind: Standard; Case/Package: LQFP; Library Name: Hieu_Lib.IntLib
J1 = Header 2.54 4P: 0.098"(2.50mm) 4 MalePin Header XH Through Hole Wire To Board / Wire To Wire Connector ROHS; Manufacturer Part Number: XH-4A; Manufacturer: BOOMELE(Boom Precision Elec); Supplier Part Number 1: C37815; Supplier 1: LCSC; Component Kind: Standard; Library Name: Hieu_Lib.IntLib
LED1 = Led 0805 Red: Red 0805 Light Emitting Diodes (LED) ROHS; Manufacturer Part Number: 17-21SURC/S530-A3/TR8; Manufacturer: Everlight Elec; Supplier Part Number 1: C72037; Supplier 1: LCSC; Component Kind: Standard; Library Name: Hieu_Lib.IntLib
LED2 = Led 0805 Yellow: Yellow SMD,2x1.25x0.8mm Light Emitting Diodes (LED) ROHS; Manufacturer Part Number: E6C0805UYAC1UDA; Manufacturer: EKINGLUX; Supplier Part Number 1: C375452; Supplier 1: LCSC; Component Kind: Standard; Library Name: Hieu_Lib.IntLib
LED3 = Led 0805 Green: Emerald 0805 Light Emitting Diodes (LED) ROHS; Manufacturer Part Number: ORH-G35A; Manufacturer: Orient; Supplier Part Number 1: C205442; Supplier 1: LCSC; Component Kind: Standard; Library Name: Hieu_Lib.IntLib
LED4 = Led 0805 White: White SMD,2x1.25x0.68mm Light Emitting Diodes (LED) ROHS; Manufacturer Part Number: HL-PC-2012H322W-L(6000K-7000K); Manufacturer: HONGLITRONIC(Hongli Zhihui (HONGLITRONIC)); Supplier Part Number 1: C497944; Supplier 1: LCSC; Component Kind: Standard; Library Name: Hieu_Lib.IntLib
R13 R17 = Res 0805 4.7k Ohm 1%: General Purpose Chip Resistor Thick Film 0805 4.7kOhm 1% Paper T/R; Manufacturer Part Number: RC0805FR-074K7L; Manufacturer: Yageo; Voltage Rating (DC): 150V; Temperature Coefficient: 100ppm/°C; Resistance: 4.7kR; Power Rating: 125mW; Width: 0.049inch; Voltage Rating: 150V; Tolerance: 1%; Termination: SMD/SMT; Technology: THICK FILM; Supplier Part Number 1: 311-4.70KCRCT-ND; Supplier 1: Digi-Key; Stock 1: 159494; Size Code: 0805; Series: RC; RoHS Compliant: Yes; RoHS: Yes; Resistor Type: Thick Film; Resistance Tolerance: 1%; REACH SVHC: No SVHC; Pricing 1: 1 = 0.10000, 10 = 0.04200, 100 = 0.01690, 1000 = 0.00759, 2500 = 0.00659 (USD); Packaging: Tape and Reel; Package Quantity: 5000; Number of Terminations: 2; Number of Pins: 2; Min Operating Temperature: -55°C; Military Standard: Not; Max Power Dissipation: 125mW; Max Operating Temperature: 155°C; Length: 0.079inch; Lead Free: Lead Free; Height: 0.024inch; Features: Moisture Resistant; Depth: 1.25mm; Construction: Rectangular; ComponentLink6URL: https://datasheet.ciiva.com/46447/444164-46447583.pdf?src-supplier=Element14; ComponentLink6Description: https://datasheet.ciiva.com/46447/444164-46447583.pdf?src-supplier=Element14; ComponentLink5URL: https://datasheet.ciiva.com/6547/pyu-r-int-thick-7-6547263.pdf?src-supplier=Digi-Key; ComponentLink5Description: https://datasheet.ciiva.com/6547/pyu-r-int-thick-7-6547263.pdf?src-supplier=Digi-Key; ComponentLink4URL: https://datasheet.ciiva.com/7941/444164-7941806.pdf?src-supplier=Element14; ComponentLink4Description: https://datasheet.ciiva.com/7941/444164-7941806.pdf?src-supplier=Element14; ComponentLink3URL: https://datasheet.ciiva.com/7941/1686128-7941805.pdf?src-supplier=Element14; ComponentLink3Description: https://datasheet.ciiva.com/7941/1686128-7941805.pdf?src-supplier=Element14; ComponentLink2URL: https://datasheet.ciiva.com/7941/444164-7941804.pdf?src-supplier=Element14; ComponentLink2Description: https://datasheet.ciiva.com/7941/444164-7941804.pdf?src-supplier=Element14; ComponentLink1URL: https://datasheet.ciiva.com/11808/0900766b8106beab-11808155.pdf?src-supplier=RSComponents; ComponentLink1Description: https://datasheet.ciiva.com/11808/0900766b8106beab-11808155.pdf?src-supplier=RSComponents; Component Kind: Standard; Case Code (Metric): 2012; Case Code (Imperial): 0805; Case/Package: 0805; Library Name: Hieu_Lib.SchLib
R14 = Res 0805 5.6 Ohm 1%: Res SMD 0805 5R6 1% 0.125W T.C.100PPM; Manufacturer Part Number: RC0805FR-075R6L; Manufacturer: Yageo; Voltage Rating: 150V; Temperature Coefficient: 200ppm/°C; Resistance: 5.6R; Power Rating: 125mW; Width: 1.25mm; Tolerance: 1%; Termination: SMD/SMT; Technology: THICK FILM; Supplier Part Number 1: 311-5.60CRCT-ND; Supplier 1: Digi-Key; Stock 1: 84478; Series: RC; RoHS Compliant: Yes; RoHS: Yes; Resistor Type: Thick Film; Resistance Tolerance: 1%; REACH SVHC: Unknown; Pricing 1: 1 = 0.10000, 10 = 0.08300, 100 = 0.03390, 1000 = 0.01521, 2500 = 0.01320 (USD); Packaging: Tape and Reel; Package Quantity: 5000; Number of Terminations: 2; Min Operating Temperature: -55°C; Military Standard: Not; Max Power Dissipation: 125mW; Max Operating Temperature: 155°C; Length: 2mm; Lead Free: Lead Free; Height: 0.5mm; Features: Moisture Resistant; Depth: 1.25mm; Construction: Rectangular; ComponentLink3URL: https://datasheet.ciiva.com/7941/444164-7941823.pdf?src-supplier=Element14; ComponentLink3Description: https://datasheet.ciiva.com/7941/444164-7941823.pdf?src-supplier=Element14; ComponentLink2URL: https://datasheet.ciiva.com/2459/pyu-r-int-thick-7-2459643.pdf?src-supplier=Digi-Key; ComponentLink2Description: https://datasheet.ciiva.com/2459/pyu-r-int-thick-7-2459643.pdf?src-supplier=Digi-Key; ComponentLink1URL: https://datasheet.ciiva.com/11808/0900766b8106beab-11808369.pdf?src-supplier=RSComponents; ComponentLink1Description: https://datasheet.ciiva.com/11808/0900766b8106beab-11808369.pdf?src-supplier=RSComponents; Component Kind: Standard; Case Code (Metric): 2012; Case Code (Imperial): 0805; Case/Package: 0805; Library Name: Hieu_Lib.IntLib
R15 R16 = Res 0805 470 Ohm 1%: Res Thick Film 0805 470 Ohm 1% 1/8W +/-100ppm/ßC Molded SMD Paper T/R; Manufacturer Part Number: RC0805FR-07470RL; Manufacturer: Yageo; Voltage Rating (DC): 150V; Temperature Coefficient: 100ppm/°C; Resistance: 470R; Power Rating: 125mW; Width: 1.25mm; Voltage Rating: 150V; Tolerance: 1%; Termination: SMD/SMT; Supplier Part Number 1: 311-470CRDKR-ND; Supplier 1: Digi-Key; Stock 1: 0; Size Code: 0805; Series: RC; RoHS Compliant: Yes; RoHS: Yes; Resistor Type: Thick Film; Resistance Tolerance: 1%; REACH SVHC: Unknown; Pricing 1: 1 = 0.10000, 10 = 0.04200, 100 = 0.01690, 1000 = 0.00759, 2500 = 0.00659 (USD); Packaging: Tape and Reel; Package Quantity: 5000; Number of Terminations: 2; Number of Pins: 2; Min Operating Temperature: -55°C; Military Standard: Not; Max Power Dissipation: 125mW; Max Operating Temperature: 155°C; Length: 2mm; Lead Pitch: 0.4mm; Lead Free: Lead Free; Height: 0.5mm; Features: Moisture Resistant; Depth: 1.25mm; Construction: Rectangular; ComponentLink7URL: https://datasheet.ciiva.com/16745/rc0805-16745229.pdf?src-supplier=Future+Electronics; ComponentLink7Description: https://datasheet.ciiva.com/16745/rc0805-16745229.pdf?src-supplier=Future+Electronics; ComponentLink6URL: https://datasheet.ciiva.com/46447/444164-46447580.pdf?src-supplier=Element14; ComponentLink6Description: https://datasheet.ciiva.com/46447/444164-46447580.pdf?src-supplier=Element14; ComponentLink5URL: https://datasheet.ciiva.com/7941/444164-7941798.pdf?src-supplier=Element14; ComponentLink5Description: https://datasheet.ciiva.com/7941/444164-7941798.pdf?src-supplier=Element14; ComponentLink4URL: https://datasheet.ciiva.com/7941/444164-7941797.pdf?src-supplier=Element14; ComponentLink4Description: https://datasheet.ciiva.com/7941/444164-7941797.pdf?src-supplier=Element14; ComponentLink3URL: https://datasheet.ciiva.com/2457/pyu-r-int-thick-7-2457058.pdf?src-supplier=Digi-Key; ComponentLink3Description: https://datasheet.ciiva.com/2457/pyu-r-int-thick-7-2457058.pdf?src-supplier=Digi-Key; ComponentLink2URL: https://datasheet.ciiva.com/2457/pyu-r-int-thick-7-2457057.pdf?src-supplier=Digi-Key; ComponentLink2Description: https://datasheet.ciiva.com/2457/pyu-r-int-thick-7-2457057.pdf?src-supplier=Digi-Key; ComponentLink1URL: https://datasheet.ciiva.com/2457/pyu-r-int-thick-7-2457056.pdf?src-supplier=Digi-Key; ComponentLink1Description: https://datasheet.ciiva.com/2457/pyu-r-int-thick-7-2457056.pdf?src-supplier=Digi-Key; Component Kind: Standard; Case Code (Metric): 2012; Case Code (Imperial): 0805; Case/Package: 0805; Library Name: Hieu_Lib.IntLib
R18 R20 R26 R27 = Res 0805 60.4 Ohm 1%: Res Thick Film 0805 60.4 Ohm 1% 0.125W(1/8W) ±100ppm/C Pad SMD T/R; Manufacturer Part Number: RC0805FR-0760R4L; Manufacturer: Yageo; Working Voltage: 150V; Temperature Coefficient: 100ppm/°C; Resistance: 60.4R; Power Rating: 125mW; Width: 0.049inch; Voltage Rating (DC): 150V; Voltage Rating: 150V; Tolerance: 1%; Termination: SMD/SMT; Technology: THICK FILM; Supplier Part Number 1: 311-60.4CRCT-ND; Supplier 1: Digi-Key; Stock 1: 27050; Series: RC; RoHS Compliant: Yes; RoHS: Yes; Resistor Type: Thick Film; Radiation Hardening: No; Pricing 1: 1 = 0.10000, 10 = 0.04200, 100 = 0.01690, 1000 = 0.00759, 2500 = 0.00659 (USD); Packaging: Tape and Reel; Package Quantity: 1; Number of Terminations: 2; Min Operating Temperature: -55°C; Military Standard: Not; Max Power Dissipation: 125mW; Max Operating Temperature: 155°C; Length: 0.079inch; Lead Free: Lead Free; Height: 0.024inch; Features: Moisture Resistant; Depth: 1.25mm; Contact Plating: Tin,Matte,Lead; Construction: Rectangular; ComponentLink3URL: https://datasheet.ciiva.com/2457/pyu-r-int-thick-7-2457215.pdf?src-supplier=Digi-Key; ComponentLink3Description: https://datasheet.ciiva.com/2457/pyu-r-int-thick-7-2457215.pdf?src-supplier=Digi-Key; ComponentLink2URL: https://datasheet.ciiva.com/2457/pyu-r-int-thick-7-2457217.pdf?src-supplier=Digi-Key; ComponentLink2Description: https://datasheet.ciiva.com/2457/pyu-r-int-thick-7-2457217.pdf?src-supplier=Digi-Key; ComponentLink1URL: https://datasheet.ciiva.com/2457/pyu-r-int-thick-7-2457216.pdf?src-supplier=Digi-Key; ComponentLink1Description: https://datasheet.ciiva.com/2457/pyu-r-int-thick-7-2457216.pdf?src-supplier=Digi-Key; Component Kind: Standard; Case Code (Metric): 2012; Case Code (Imperial): 0805; Case/Package: 0805; Library Name: Hieu_Lib.IntLib
R19 R23-R25 = Res 0805 220 Ohm 1%: Res SMD 0805 220R 1% 0.125W T.C.100PPM; Manufacturer Part Number: RC0805FR-07220RL; Manufacturer: Yageo; Voltage Rating: 150V; Temperature Coefficient: 100ppm/°C; Power Rating: 125mW; Width: 0.049inch; Tolerance: 1%; Termination: SMD/SMT; Technology: THICK FILM; Supplier Part Number 1: 311-220CRCT-ND; Supplier 1: Digi-Key; Stock 1: 316498; Size Code: 0805; Series: RC; RoHS Compliant: Yes; RoHS: Yes; Resistor Type: Thick Film; Resistance Tolerance: 1%; Resistance: 220R; REACH SVHC: Unknown; Pricing 1: 1 = 0.10000, 10 = 0.04200, 100 = 0.01690, 1000 = 0.00759, 2500 = 0.00659 (USD); Packaging: Tape and Reel; Package Quantity: 5000; Number of Terminations: 2; Min Operating Temperature: -55°C; Military Standard: Not; Max Power Dissipation: 125mW; Max Operating Temperature: 155°C; Length: 2mm; Lead Free: Lead Free; Height: 0.5mm; Features: Moisture Resistant; Depth: 1.25mm; Construction: Rectangular; ComponentLink6URL: https://datasheet.ciiva.com/7941/444164-7941741.pdf?src-supplier=Element14; ComponentLink6Description: https://datasheet.ciiva.com/7941/444164-7941741.pdf?src-supplier=Element14; ComponentLink5URL: https://datasheet.ciiva.com/7941/444164-7941740.pdf?src-supplier=Element14; ComponentLink5Description: https://datasheet.ciiva.com/7941/444164-7941740.pdf?src-supplier=Element14; ComponentLink4URL: https://datasheet.ciiva.com/2457/pyu-r-int-thick-7-2457385.pdf?src-supplier=Digi-Key; ComponentLink4Description: https://datasheet.ciiva.com/2457/pyu-r-int-thick-7-2457385.pdf?src-supplier=Digi-Key; ComponentLink3URL: https://datasheet.ciiva.com/2457/pyu-r-int-thick-7-2457384.pdf?src-supplier=Digi-Key; ComponentLink3Description: https://datasheet.ciiva.com/2457/pyu-r-int-thick-7-2457384.pdf?src-supplier=Digi-Key; ComponentLink2URL: https://datasheet.ciiva.com/2457/pyu-r-int-thick-7-2457383.pdf?src-supplier=Digi-Key; ComponentLink2Description: https://datasheet.ciiva.com/2457/pyu-r-int-thick-7-2457383.pdf?src-supplier=Digi-Key; ComponentLink1URL: https://datasheet.ciiva.com/11808/0900766b8106beab-11808180.pdf?src-supplier=RSComponents; ComponentLink1Description: https://datasheet.ciiva.com/11808/0900766b8106beab-11808180.pdf?src-supplier=RSComponents; Component Kind: Standard; Case Code (Metric): 2012; Case Code (Imperial): 0805; Case/Package: 0805; Library Name: Hieu_Lib.IntLib
R21 R22 = Res 0805 1k Ohm 1%: Res Thick Film 0805 1KOhm 1% 0.125W(1/8W) 100ppm/C Epoxy Pad SMD T/R; Manufacturer Part Number: RC0805FR-071KL; Manufacturer: Yageo; Voltage Rating (DC): 150V; Temperature Coefficient: 100ppm/°C; Resistance: 1kR; Power Rating: 125mW; Width: 0.049inch; Voltage Rating: 150V; Tolerance: 1%; Termination: SMD/SMT; Supplier Part Number 1: 311-1.00KCRCT-ND; Supplier 1: Digi-Key; Stock 1: 2324983; Size Code: 0805; Series: RC; RoHS Compliant: Yes; RoHS: Yes; Resistor Type: Thick Film; Resistance Tolerance: 1%; REACH SVHC: Unknown; Pricing 1: 1 = 0.10000, 10 = 0.04200, 100 = 0.01690, 1000 = 0.00759, 2500 = 0.00659 (USD); Packaging: Tape and Reel; Package Quantity: 1; Number of Terminations: 2; Number of Pins: 2; Min Operating Temperature: -55°C; Military Standard: Not; Max Power Dissipation: 125mW; Max Operating Temperature: 155°C; Length: 0.079inch; Lead Free: Lead Free; Height: 0.024inch; Features: Moisture Resistant; Depth: 1.25mm; Construction: Rectangular; Component Kind: Standard; Case Code (Metric): 2012; Case Code (Imperial): 0805; Case/Package: 0805; Library Name: Hieu_Lib.IntLib
SW1 = Tactile Switch: PTS636 Series SPST-NO Off-Mom 180 gf Top Actuated F Lead SMT Tactile Switch; Manufacturer Part Number: PTS636SM25FSMTRLFS; Manufacturer: ITT C&K; Supplier Part Number 1: CKN12329-1-ND; Supplier 1: Digi-Key; Stock 1: 0; RoHS: No; Pricing: 1 = 0.10810; Component Kind: Standard; Library Name: Hieu_Lib.IntLib
X1 = Crystal 8Mhz 12pF: Crystal 8MHz ±20ppm (Tol) ±30ppm (Stability) 12pF FUND 400Ohm 4-Pin Mini-CSMD T/R; Manufacturer Part Number: ECS-80-12-33-JGN-TR; Manufacturer: ECS International; Supplier Part Number 1: 50-ECS-80-12-33-JGN-CT-ND; Supplier 1: Digi-Key; Stock 1: 72066; RoHS: Yes; Pricing 1: 1 = 0.65000, 10 = 0.57500, 50 = 0.53760, 100 = 0.47500, 500 = 0.45000 (USD); Component Kind: Standard; Library Name: Hieu_Lib.SchLib
